# Altium schematic sheet PowerSupply.SchDoc
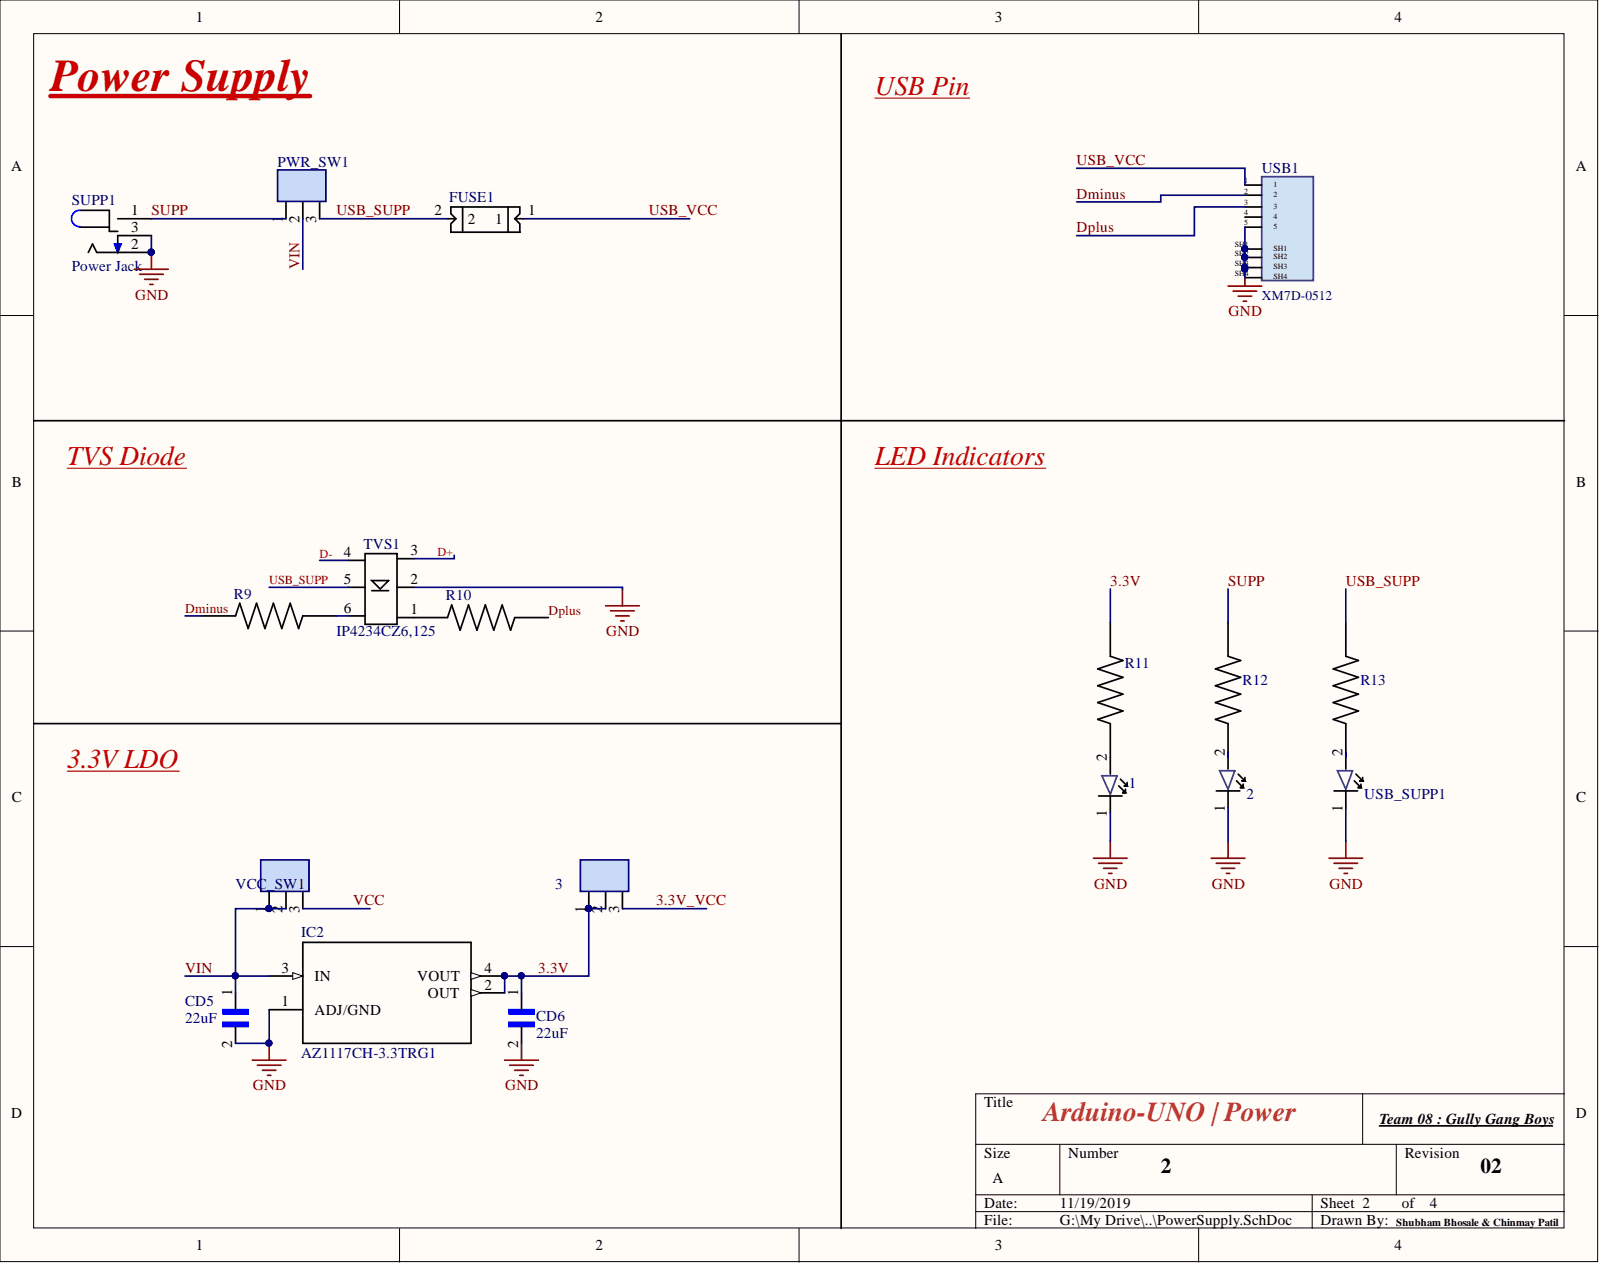
[diagram p0: PowerSupply.SchDoc]

## Parts
1  LTST-C150CKT  [LED]  (value not drawn)
2  LTST-C150CKT  [LED]  (value not drawn)
3  PREC003SAAN-RC  [3_PIN]  (value not drawn)
CD5  22uF  [1206_Passive]
CD6  22uF  [1206_Passive]
FUSE1  MF-MSMF050-2  [FUSE]  (value not drawn)
IC2  AZ1117CH-3.3TRG1  [LDO]
PWR_SW1  PREC003SAAN-RC  [3_PIN]  (value not drawn)
R9  RMCF1206JT300R‎  [1206_Passive]  (value not drawn)
R10  RMCF1206JT300R‎  [1206_Passive]  (value not drawn)
R11  RNCP1206FTD1K00  [1206_Passive]  (value not drawn)
R12  RNCP1206FTD1K00  [1206_Passive]  (value not drawn)
R13  RNCP1206FTD1K00  [1206_Passive]  (value not drawn)
SUPP1  Power Jack  [Power_Jack]
TVS1  IP4234CZ6,125  [TVS]
USB1  XM7D-0512  [USB]
USB_SUPP1  LTST-C150CKT  [LED]  (value not drawn)
VCC_SW1  PREC003SAAN-RC  [3_PIN]  (value not drawn)

## Nets
GND: 1.1 2.1 CD5.2 CD6.2 IC2.1(ADJ/GND) SUPP1.2 SUPP1.3 TVS1.2 USB1.5 USB1.SH1 USB1.SH2 USB1.SH3 USB1.SH4 USB_SUPP1.1
3.3V: 3.1 3.2 CD6.1 IC2.2(OUT) IC2.4(VOUT) R11.2
3.3V_VCC: 3.3
D+: TVS1.3
D-: TVS1.4
Dminus: R9.1 USB1.2
Dplus: R10.2 USB1.3
SUPP: PWR_SW1.1 R12.1 SUPP1.1
USB_SUPP: FUSE1.2 PWR_SW1.3 R13.1 TVS1.5
USB_VCC: FUSE1.1 USB1.1
VCC: VCC_SW1.3
VIN: CD5.1 IC2.3(IN) PWR_SW1.2 VCC_SW1.1 VCC_SW1.2
Net1_2: 1.2 R11.1
Net2_2: 2.2 R12.2
NetR9_2: R9.2 TVS1.6
NetR10_1: R10.1 TVS1.1
NetR13_2: R13.2 USB_SUPP1.2

Not connected: USB1 4

## Library data of the parts
1 2 USB_SUPP1 = 1206_RED_LED: Red 638nm LED Indication - Discrete 1.8V 1206 (3216 Metric); Value: LED RED CLEAR 1206 SMD; Component Kind: Standard; Digikey Link: https://www.digikey.com/product-detail/en/LTST-C150CKT/160-1167-1-ND/269239/?itemSeq=304982368; Digikey Part: 160-1167-1-ND; Library Name: brd2_rev2.IntLib
3 PWR_SW1 VCC_SW1 = 3_PIN: Connector Header Through Hole 3 position 0.100" (2.54mm); Value: CONN HEADER VERT 3POS 2.54MM; Component Kind: Standard; Digikey Link: https://www.digikey.com/product-detail/en/PREC003SAAN-RC/S1012EC-03-ND/2774851/?itemSeq=304982371; Digikey Part: S1012EC-03-ND; Library Name: brd2_rev2.IntLib
CD5 CD6 = 1206_22uF: 22µF ±20% 16V Ceramic Capacitor X5R 1206 (3216 Metric); Value: CAP CER 22UF 16V X5R 1206; Component Kind: Standard; Digikey Link: https://www.digikey.com/product-detail/en/CL31A226MOCLNNC/1276-2728-1-ND/3890814/?itemSeq=304982363; Digikey Part: 1276-2728-1-ND; Library Name: brd2_rev2.IntLib
FUSE1 = Fuse: Polymeric PTC Resettable Fuse 15V 500mA Ih Surface Mount 1812 (4532 Metric), Concave; Value: PTC RESET FUSE 15V 500MA 1812; Component Kind: Standard; Digikey Link: https://www.digikey.com/product-detail/en/MF-MSMF050-2/MF-MSMF050-2CT-ND/662842/?itemSeq=304982360; Digikey Part: MF-MSMF050-2CT-ND; Library Name: brd2_rev2.IntLib
IC2 = 3.3V_LDO: Linear Voltage Regulator IC Positive Fixed 1 Output 1A SOT-223; Value: IC REG LINEAR 3.3V 800MA SOT223; Component Kind: Standard; Digikey Link: https://www.digikey.com/product-detail/en/AZ1117CH-3.3TRG1/AZ1117CH-3.3TRG1DICT-ND/4505206/?itemSeq=304982370; Digikey Part: AZ1117CH-3.3TRG1DICT-ND; Library Name: brd2_rev2.IntLib
R9 R10 = 1206_300Ohm: 300 Ohms ±5% 0.25W, 1/4W Chip Resistor 1206 (3216 Metric) Automotive AEC-Q200 Thick Film; Value: 300Ohm; Component Kind: Standard; Digikey Link: https://www.digikey.com/product-detail/en/RMCF1206JT300R/RMCF1206JT300RCT-ND/1942776/?itemSeq=304982374; Digikey Part: RMCF1206JT300RCT-ND; Library Name: brd2_rev2.IntLib
R11-R13 = 1206_1K: 1 kOhms ±1% 0.5W, 1/2W Chip Resistor 1206 (3216 Metric) Anti-Sulfur, Moisture Resistant Thin Film; Value: RES 1K OHM 1% 1/2W 1206; Component Kind: Standard; Digikey Link: https://www.digikey.com/product-detail/en/RNCP1206FTD1K00/RNCP1206FTD1K00CT-ND/2240675/?itemSeq=304982373; Digikey Part: RNCP1206FTD1K00CT-ND; Library Name: brd2_rev2.IntLib
SUPP1 = Power Jack: Power Barrel Connector Jack 2.10mm ID (0.083"), 5.50mm OD (0.217") Through Hole, Right Angle; Value: CONN PWR JACK 2X5.5MM SOLDER; Component Kind: Standard; Digikey Link: https://www.digikey.com/product-detail/en/PJ-102A/CP-102A-ND/275425/?itemSeq=304982367; Digikey Part: CP-102A-ND; Library Name: brd2_rev2.IntLib
TVS1 = TVS_Diode: Clamp Ipp Tvs Diode Surface Mount 6-TSOP; Value: TVS DIODE 5.5V 6TSOP; Component Kind: Standard; Digikey Link: https://www.digikey.com/product-detail/en/IP4234CZ6%2c125/1727-4717-1-ND/2531156/?itemSeq=304982369; Digikey Part: 1727-4717-1-ND; Library Name: brd2_rev2.IntLib
USB1 = USB: USB - mini B USB 2.0 Receptacle Connector 5 Position Through Hole, Right Angle; Value: CONN RCPT USB2.0 MINI B 5P R/A; Component Kind: Standard; Digikey Link: https://www.digikey.com/product-detail/en/XM7D-0512/OR1205-ND/4695531/?itemSeq=304982356; Digikey Part: OR1205-ND; Library Name: brd2_rev2.IntLib
